# Altium schematic sheet HANC_Analog_TS.SchDoc(HANC_Analog_TS)
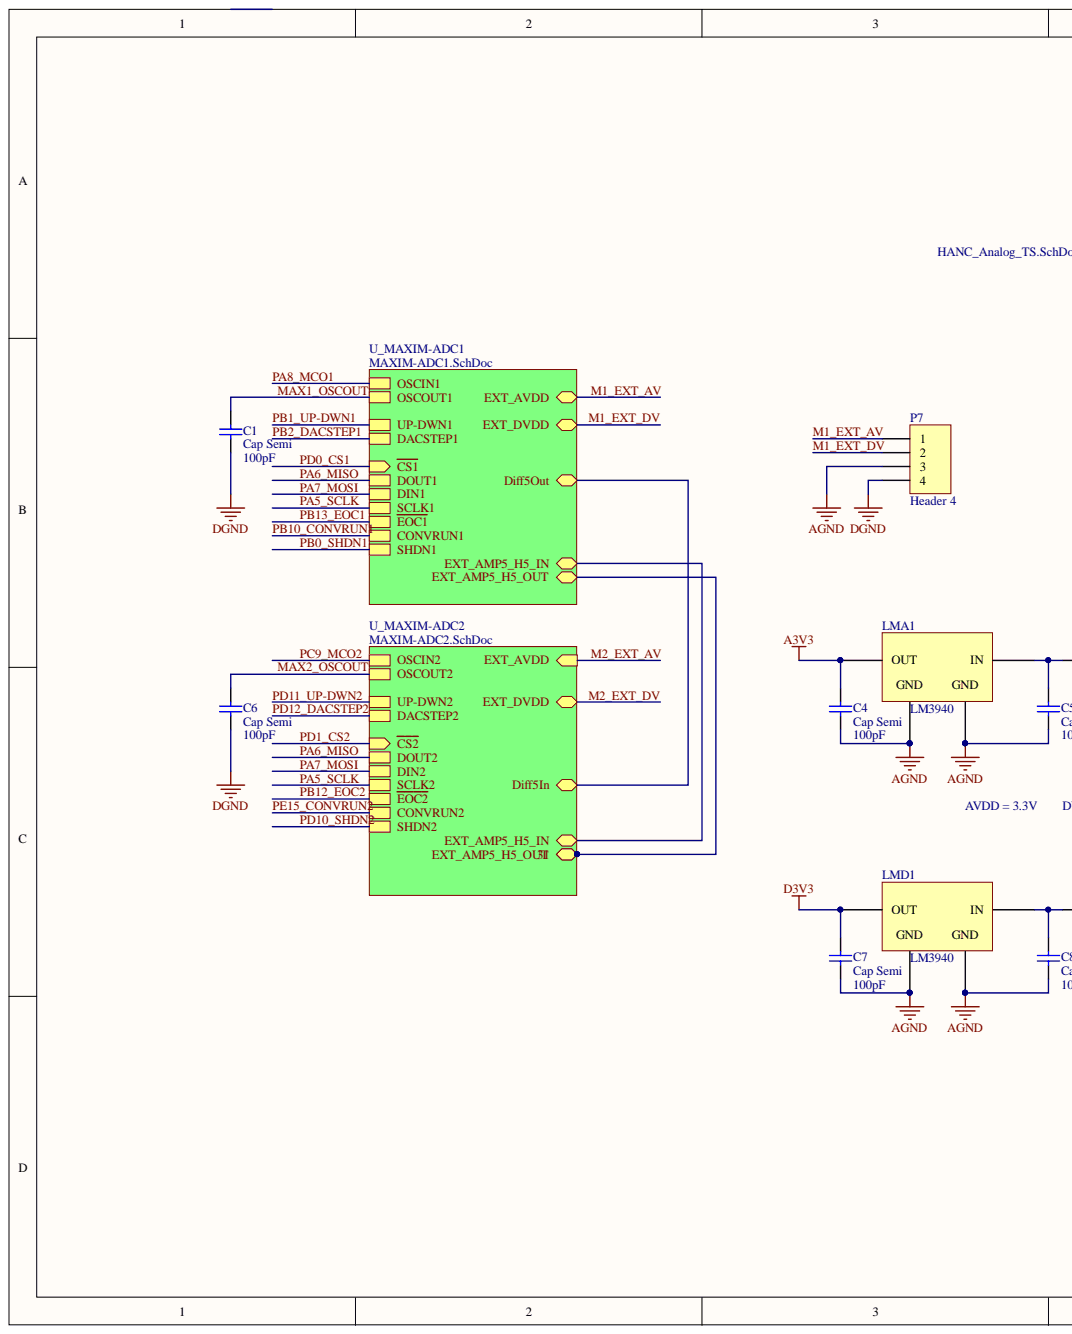
[diagram p0: HANC_Analog_TS.SchDoc, left]
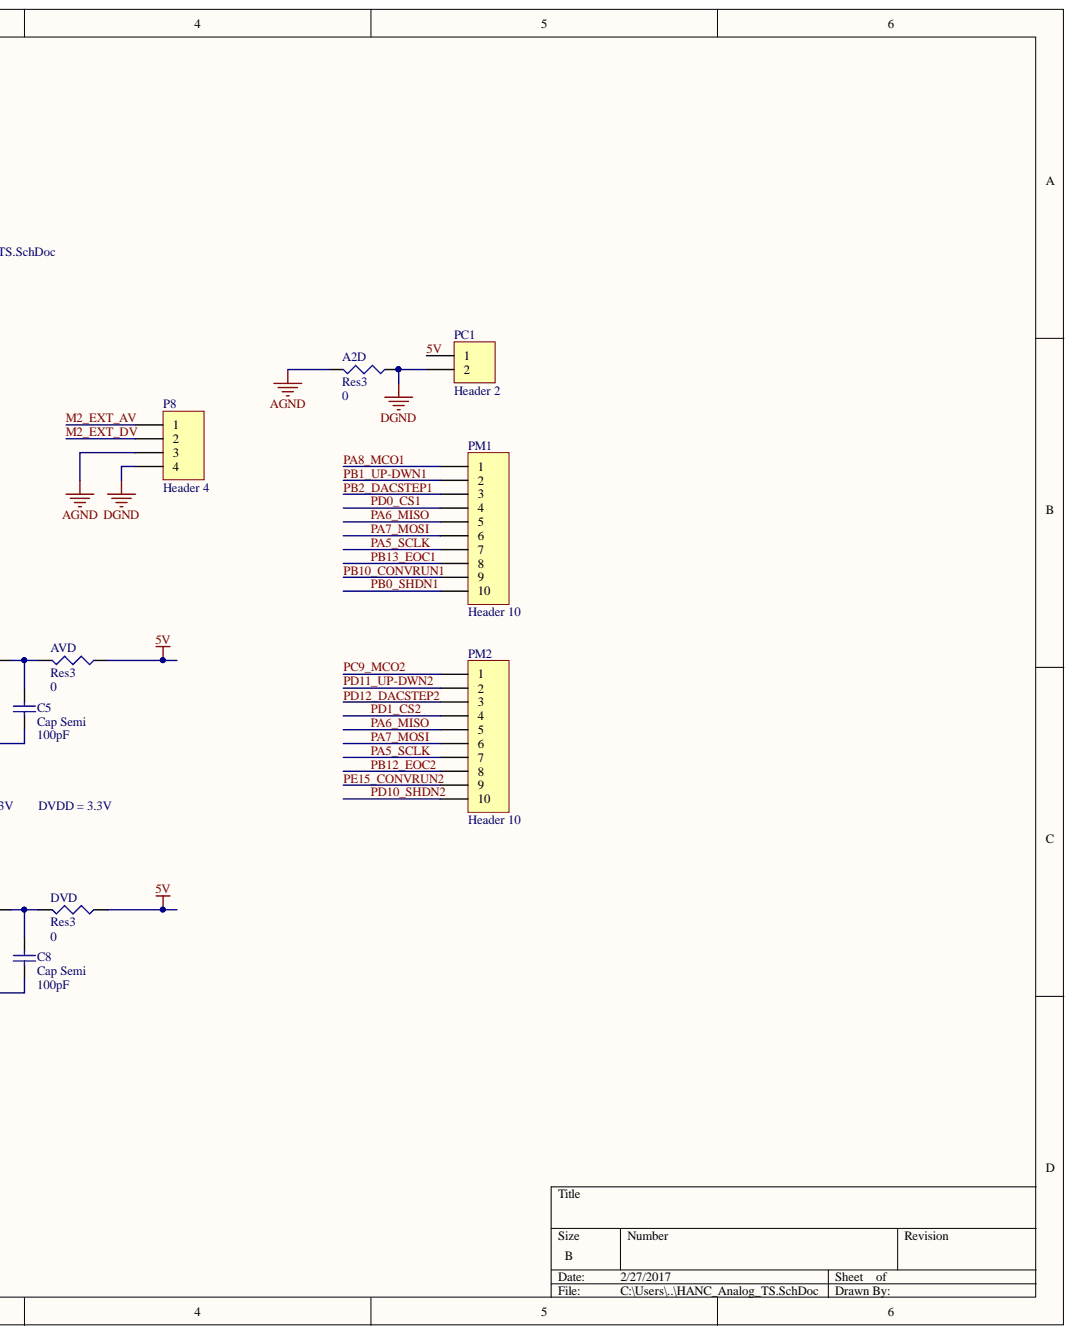
[diagram p1: HANC_Analog_TS.SchDoc, right]

## Parts
A2D  Res3  value 0  [J1-0603]
AVD  Res3  [J1-0603]
C1  Cap Semi  value 100pF  [1608[0603]]
C4  Cap Semi  value 100pF  [1608[0603]]
C5  Cap Semi  value 100pF  [1608[0603]]
C6  Cap Semi  value 100pF  [1608[0603]]
C7  Cap Semi  value 100pF  [1608[0603]]
C8  Cap Semi  value 100pF  [1608[0603]]
DVD  Res3  [J1-0603]
LMA1  LM3940  [SOT223_N]
LMD1  LM3940  [SOT223_N]
P7  Header 4  [HDR1X4]
P8  Header 4  [HDR1X4]
PC1  Header 2  [HDR1X2]
PM1  Header 10  [HDR1X10]
PM2  Header 10  [HDR1X10]

## Nets
A3V3: C4.2 LMA1.3(OUT)
AGND: A2D.2 C4.1 C5.1 C7.1 C8.1 LMA1.2 LMA1.4 LMD1.2 LMD1.4 P7.3 P8.3
D3V3: C7.2 LMD1.3(OUT)
DGND: A2D.1 C1.1 C6.1 P7.4 P8.4 PC1.2
5V: AVD.1 DVD.1 PC1.1
M1_EXT_AV: P7.1
M1_EXT_DV: P7.2
M2_EXT_AV: P8.1
M2_EXT_DV: P8.2
MAX1_OSCOUT: C1.2
MAX2_OSCOUT: C6.2
PA5_SCLK: PM1.7 PM2.7
PA6_MISO: PM1.5 PM2.5
PA7_MOSI: PM1.6 PM2.6
PA8_MCO1: PM1.1
PB0_SHDN1: PM1.10
PB1_UP-DWN1: PM1.2
PB2_DACSTEP1: PM1.3
PB10_CONVRUN1: PM1.9
PB12_EOC2: PM2.8
PB13_EOC1: PM1.8
PC9_MCO2: PM2.1
PD0_CS1: PM1.4
PD1_CS2: PM2.4
PD10_SHDN2: PM2.10
PD11_UP-DWN2: PM2.2
PD12_DACSTEP2: PM2.3
PE15_CONVRUN2: PM2.9
NetAVD_2: AVD.2 C5.2 LMA1.1(IN)
NetC8_2: C8.2 DVD.2 LMD1.1(IN)
DIFF5OUT:
EXT_AMP5_H5_IN:
EXT_AMP5_H5_OUT:
N00015:

## Library data of the parts
A2D = Res3: Resistor; Component Kind: Standard; LatestRevisionDate: 29-May-2009; LatestRevisionNote: IPC-7351 Footprint Added.; Library Name: Miscellaneous Devices.IntLib; PackageDescription: Chip Resistor; PackageReference: J1-0603; Published: 8-Jun-2000; Signal Integrity: Res; Simulation: RESISTOR; Publisher: Altium Limited
AVD DVD = Res3: Resistor; Value: 0; Component Kind: Standard; LatestRevisionDate: 29-May-2009; LatestRevisionNote: IPC-7351 Footprint Added.; Library Name: Miscellaneous Devices.IntLib; PackageDescription: Chip Resistor; PackageReference: J1-0603; Published: 8-Jun-2000; Signal Integrity: Res; Simulation: RESISTOR; Publisher: Altium Limited
C1 C4-C8 = Cap Semi: Capacitor (Semiconductor SIM Model); Component Kind: Standard; LatestRevisionDate: 29-May-2009; LatestRevisionNote: IPC-7351 Footprint Added.; Library Name: Miscellaneous Devices.IntLib; PackageDescription: Chip Capacitor; PackageReference: C1206; Published: 8-Jun-2000; Signal Integrity: Cap Semi; Simulation: CAP; Publisher: Altium Limited
LMA1 LMD1 = Component_1: Component Kind: Standard; Library Name: LN3940.SchLib
P7 = Header 4: External power for ADC1; Component Kind: Standard; LatestRevisionDate: 17-Jul-2002; LatestRevisionNote: Re-released for DXP Platform.; Library Name: Miscellaneous Connectors.IntLib; Signal Integrity: Connector; Publisher: Altium Limited
P8 = Header 4: External power for ADC2; Component Kind: Standard; LatestRevisionDate: 17-Jul-2002; LatestRevisionNote: Re-released for DXP Platform.; Library Name: Miscellaneous Connectors.IntLib; Signal Integrity: Connector; Publisher: Altium Limited
PC1 = Header 2: Power for analog board; Component Kind: Standard; LatestRevisionDate: 17-Jul-2002; LatestRevisionNote: Re-released for DXP Platform.; Library Name: Miscellaneous Connectors.IntLib; Signal Integrity: Connector; Publisher: Altium Limited
PM1 = Header 10: GPIO for ADC1; Component Kind: Standard; LatestRevisionDate: 17-Jul-2002; LatestRevisionNote: Re-released for DXP Platform.; Library Name: Miscellaneous Connectors.IntLib; Signal Integrity: Connector; Publisher: Altium Limited
PM2 = Header 10: GPIO for ADC2; Component Kind: Standard; LatestRevisionDate: 17-Jul-2002; LatestRevisionNote: Re-released for DXP Platform.; Library Name: Miscellaneous Connectors.IntLib; Signal Integrity: Connector; Publisher: Altium Limited
